# Altium schematic sheet 05_Analog.SchDoc
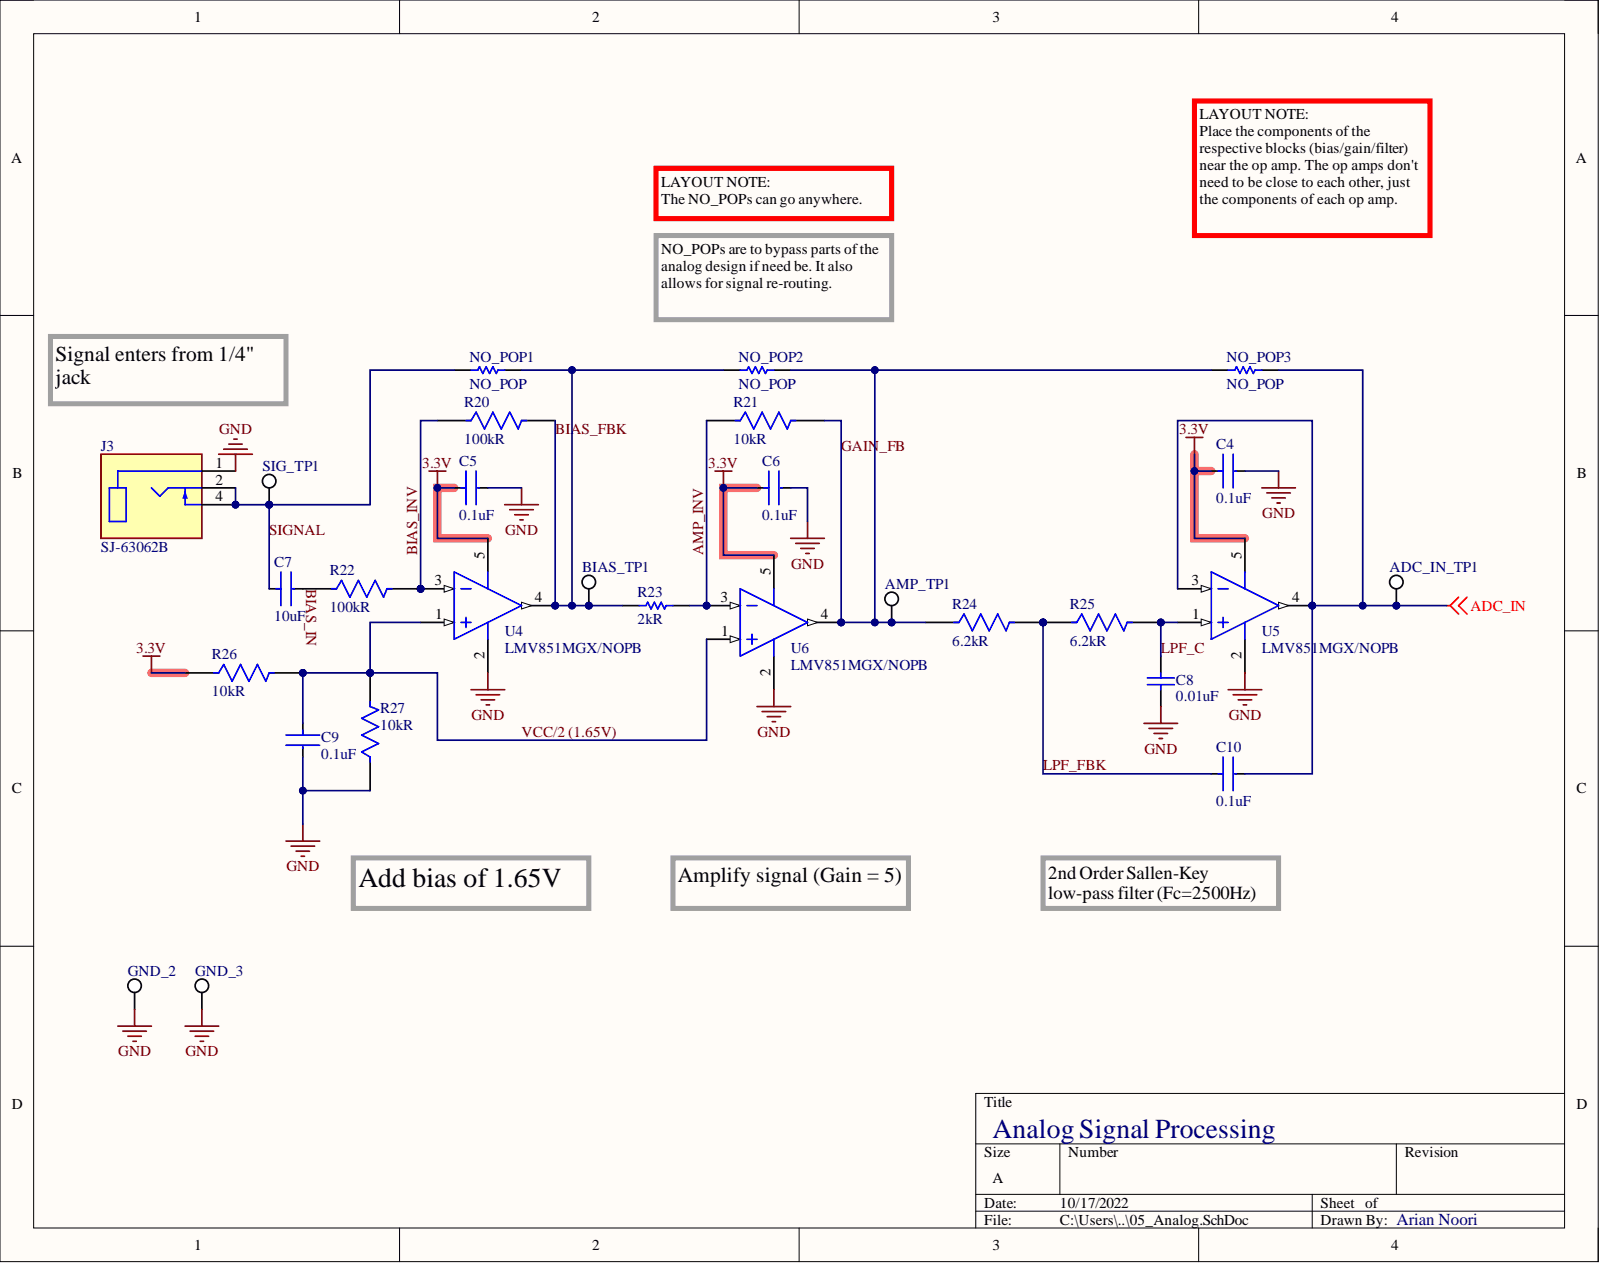
[diagram p0: 05_Analog.SchDoc]

## Parts
ADC_IN_TP1  5019  [KSTN-5019_V]  (value not drawn)
AMP_TP1  5019  [KSTN-5019_V]  (value not drawn)
BIAS_TP1  5019  [KSTN-5019_V]  (value not drawn)
C4  0.1uF  [FP-CL21-IPC_C]
C5  0.1uF  [FP-CL21-IPC_C]
C6  0.1uF  [FP-CL21-IPC_C]
C7  10uF  [FP-CL21-IPC_B]
C8  0.01uF  [CAPC2013X75X45LL10T25]
C9  0.1uF  [FP-CL21-IPC_C]
C10  0.1uF  [FP-CL21-IPC_C]
GND_2  5019  [KSTN-5019_V]  (value not drawn)
GND_3  5019  [KSTN-5019_V]  (value not drawn)
J3  SJ-63062B  [CUI_SJ-63062B]
NO_POP1  NO_POP  [RESC2013X60X35ML10T20]
NO_POP2  NO_POP  [RESC2013X60X35ML10T20]
NO_POP3  NO_POP  [RESC2013X60X35ML10T20]
R20  100kR  [FP-RT0805-MFG]
R21  10kR  [FP-RT0805-MFG]
R22  100kR  [FP-RT0805-MFG]
R23  2kR  [RESC2013X60X35LL10T20]
R24  6.2kR  [FP-RT0805-MFG]
R25  6.2kR  [FP-RT0805-MFG]
R26  10kR  [FP-RT0805-MFG]
R27  10kR  [FP-RT0805-MFG]
SIG_TP1  5019  [KSTN-5019_V]  (value not drawn)
U4  LMV851MGX/NOPB  [DCK0005A_N]
U5  LMV851MGX/NOPB  [DCK0005A_N]
U6  LMV851MGX/NOPB  [DCK0005A_N]

## Nets
3.3V: C4.1 C5.1 C6.1 R26.1 U4.5 U5.5 U6.5
ADC_IN: ADC_IN_TP1.1 C10.2 NO_POP3.2 U5.3 U5.4
GND: C4.2 C5.2 C6.2 C8.2 C9.2 GND_2.1 GND_3.1 J3.1 R27.1 U4.2 U5.2 U6.2
AMP_INV: R21.1 R23.2 U6.3
BIAS_FBK: BIAS_TP1.1 NO_POP1.2 NO_POP2.1 R20.2 R23.1 U4.4
BIAS_IN: C7.2 R22.1
BIAS_INV: R20.1 R22.2 U4.3
GAIN_FB: AMP_TP1.1 NO_POP2.2 NO_POP3.1 R21.2 R24.1 U6.4
LPF_C: C8.1 R25.2 U5.1
LPF_FBK: C10.1 R24.2 R25.1
SIGNAL: C7.1 J3.2 J3.4 NO_POP1.1 SIG_TP1.1
VCC/2 (1.65V): C9.1 R26.2 R27.2 U4.1 U6.1

## Library data of the parts
ADC_IN_TP1 AMP_TP1 BIAS_TP1 GND_2 GND_3 SIG_TP1 = CMP-1672-00008-1: Test Point, 1 Position SMD, RoHS, Tape and Reel; Manufacturer: Keystone Electronics; Category: Connectors; Component Kind: Standard; ComponentLink1Description: Manufacturer URL; ComponentLink1URL: http://www.keyelco.com/; ComponentLink2Description: Datasheet; ComponentLink2URL: http://www.keyelco.com/product-pdf.cfm?p=1357; ComponentLink3Description: https://datasheet.ciiva.com/16318/m60-2p61-16318395.pdf?src-supplier=Future+Electronics; ComponentLink3URL: https://datasheet.ciiva.com/16318/m60-2p61-16318395.pdf?src-supplier=Future+Electronics; ComponentLink4Description: https://datasheet.ciiva.com/16885/70182998-16885945.pdf?src-supplier=Allied+Electronics; ComponentLink4URL: https://datasheet.ciiva.com/16885/70182998-16885945.pdf?src-supplier=Allied+Electronics; ComponentLink5Description: https://datasheet.ciiva.com/14848/m60-2p61-14848437.pdf?src-supplier=Digi-Key; ComponentLink5URL: https://datasheet.ciiva.com/14848/m60-2p61-14848437.pdf?src-supplier=Digi-Key; ComponentLink6Description: https://datasheet.ciiva.com/14848/m60-2p61-14848438.pdf?src-supplier=Digi-Key; ComponentLink6URL: https://datasheet.ciiva.com/14848/m60-2p61-14848438.pdf?src-supplier=Digi-Key; ComponentLink7Description: https://datasheet.ciiva.com/14848/m60-2p61-14848439.pdf?src-supplier=Digi-Key; ComponentLink7URL: https://datasheet.ciiva.com/14848/m60-2p61-14848439.pdf?src-supplier=Digi-Key; Contact Material: Bronze; Contact Plating: Silver; DatasheetVersion: 03/2014; Height: 0.09inch; Lead Free: Lead Free; Library Name: Altium Content Vault; Manufacturer Part Number: 5019; Material: Bronze; Mount: Surface Mount; Mounting Technology: Surface Mount; Number of Pins: 1; Package Quantity: 1000; PackageDescription: 1-Pin Surface Mount Device, Body 3.81 x 2.03 mm; PackageReference: 5019; Packaging: Tape and Reel; Pins: 1; Pricing 1: 1=0.34000, 25=0.27360, 100=0.22340, 250=0.20064, 500=0.19152 (USD); REACH SVHC: No SVHC; RoHS: Yes; RoHS Compliant: Yes; RoHSCompliant: True; Series: 5019; Stock 1: 1295398; Supplier 1: Digi-Key; Termination: SMD/SMT
C4-C6 C9 C10 = CMP-2000-05017-2: CL21 Series 0805 100nF 50V ±10% Tolerance X7R Multilayer Ceramic Chip Capacitor; Manufacturer: Samsung; Manufacturer Part Number: CL21B104KBCNNNC; Capacitance: 100nF; Case Code (Imperial): 0805; Case Code (Metric): 2012; Case/Package: 0805; Category: Ceramic Capacitors; Component Kind: Standard; ComponentLink1Description: https://datasheet.ciiva.com/25710/cl21b104kbcnnnc-25710182.pdf?src-supplier=Digi-Key; ComponentLink1URL: https://datasheet.ciiva.com/25710/cl21b104kbcnnnc-25710182.pdf?src-supplier=Digi-Key; ComponentLink2Description: https://datasheet.ciiva.com/29199/454152-da-01-en-vs-kondensator-0805-29199814.pdf?src-supplier=Conrad; ComponentLink2URL: https://datasheet.ciiva.com/29199/454152-da-01-en-vs-kondensator-0805-29199814.pdf?src-supplier=Conrad; Construction: Flat; Contact Plating: Tin; Depth: 1.25mm; Dielectric: X7R; Height: 0.85mm; Lead Free: Lead Free; Length: 2mm; Library Name: Altium Content Vault; Material: Ceramic; Max Operating Temperature: 125°C; Min Operating Temperature: -55°C; Mount: Surface Mount; Packaging: Tape and Reel; Pricing 1: 1=0.10000, 10=0.04400, 50=0.02400, 100=0.01960, 500=0.01404, 1000=0.01103 (USD); Radiation Hardening: No; RoHS: Yes; RoHS Compliant: Yes; Series: CL; Stock 1: 4599648; Supplier 1: Digi-Key; Thickness: 0.037inch; Tolerance: 10%; Voltage: 50V; Voltage Rating: 50V; Voltage Rating (DC): 50V; Width: 0.049inch
C7 = CMP-2000-06103-2: CL21 Series 0805 10uF 10V ±10% Tolerance X5R Multilayer Ceramic Chip Capacitor; Manufacturer: Samsung; Manufacturer Part Number: CL21A106KPFNNNE; Capacitance: 10uF; Case Code (Imperial): 0805; Case Code (Metric): 2012; Case/Package: 0805; Category: Ceramic Capacitors; Component Kind: Standard; ComponentLink1Description: https://media.digikey.com/pdf/Data%20Sheets/Samsung%20PDFs/CL_Series_MLCC_ds.pdf?src-supplier=Digi-Key; ComponentLink1URL: https://media.digikey.com/pdf/Data%20Sheets/Samsung%20PDFs/CL_Series_MLCC_ds.pdf?src-supplier=Digi-Key; Construction: Flat; Contact Plating: Tin; Depth: 1.25mm; Dielectric: X5R; Height: 1.25mm; Lead Free: Lead Free; Length: 2mm; Library Name: Altium Content Vault; Max Operating Temperature: 85°C; Min Operating Temperature: -55°C; Mount: Surface Mount; Package Quantity: 1; Packaging: Tape and Reel; Pricing 1: 1=0.11000, 10=0.08100, 50=0.04420, 100=0.03610, 500=0.02580, 1000=0.02028 (USD); Radiation Hardening: No; RoHS: Yes; RoHS Compliant: Yes; Series: CL; Stock 1: 2691681; Supplier 1: Digi-Key; Thickness: 0.053inch; Tolerance: 10%; Voltage Rating: 10V; Voltage Rating (DC): 10V; Width: 0.049inch
C8 = CMP-2000-06105-1: Multilayer Ceramic Capacitor, 10 nF, 50 V, -55 to 125 degC, 2-Pin SMD, RoHS, Tape and Reel; Manufacturer: Samsung Electro-Mechanics; Manufacturer Part Number: CL21B103KBANNNC; Capacitance: 10000pF; Dielectric: X7R; Rated Voltage: 50V; Tolerance: 10%; Tolerance (%): ±10%; Case Code (Imperial): 0805; Case Code (Metric): 2012; Case Size/Dimension: 0805; Case/Package: 0805; Category: Ceramic Capacitors; Component Kind: Standard; ComponentLink1Description: https://datasheet.ciiva.com/25709/cl21b103kbannnc-25709781.pdf?src-supplier=Digi-Key; ComponentLink1URL: https://datasheet.ciiva.com/25709/cl21b103kbannnc-25709781.pdf?src-supplier=Digi-Key; ComponentLink2Description: https://datasheet.ciiva.com/29199/455082-da-01-en-vs-kondensator-0805-29199459.pdf?src-supplier=Conrad; ComponentLink2URL: https://datasheet.ciiva.com/29199/455082-da-01-en-vs-kondensator-0805-29199459.pdf?src-supplier=Conrad; Construction: Flat; Contact Plating: Tin; Datasheet URL: https://datasheet.ciiva.com/29199/455082-da-01-en-vs-kondensator-0805-29199459.pdf; Datasheet Version: 11/2010; Depth: 1.25mm; Height: 0.65mm; Lead Free: Lead Free; Length: 2mm; Library Name: Altium Content Vault; Manufacturer URL: http://www.samsungsem.com/global/index.jsp; Material: Ceramic; Max Operating Temperature: 125°C; Min Operating Temperature: -55°C; Mount: Surface Mount; Mounting Technology: SurfaceMount; Package Description: 2-Pin Surface Mount Device, Body 2 x 1.25 mm; Package Reference: 0805; Packaging: Tape and Reel; Pricing 1: 1=0.10000, 10=0.04200, 50=0.02300, 100=0.01880, 500=0.01344, 1000=0.01055 (USD); Radiation Hardening: No; RoHS: Yes; RoHS Compliant: Yes; Series: CL; Stock 1: 3435994; Supplier 1: Digi-Key; Thickness: 0.03inch; Voltage: 50V; Voltage Rating: 50V; Voltage Rating (DC): 50V; Width: 0.049inch
J3 = SJ-63062B: 6.35 mm, Mono and Stereo, Right Angle, Through Hole, 2~3 Conductors, 0~1 Internal Switches, Audio Jack Connector; Manufacturer: CUI Devices; Availability: Unavailable; Category: Audio / Video Connectors; Component Kind: Standard; Library Name: SJ-63062B.SchLib; Manufacturer Part Number: SJ-63062B; MP: SJ-63062B; Package: None; Price: None; Pricing 1: 1=2.09000, 10=1.82100, 25=1.74360, 50=1.70500, 150=1.62747, 300=1.47250, 600=1.35625, 1050=1.16250, 2550=1.08500 (USD); RoHS: Yes; Stock 1: 732; Supplier 1: Digi-Key
NO_POP1-NO_POP3 = CMP-2100-03673-1: Chip Resistor, 0 Ohm, Jumper, +/- 5%, 0.125 W, -55 to 155 degC, 0805 (2012 Metric), RoHS, Tape and Reel; Manufacturer: Yageo; Manufacturer Part Number: RC0805JR-070RL; Max Power Dissipation: 125mW; Resistance: 0mOhm; Temperature Coefficient: 200ppm/degreesC; Voltage Rating: 150V; Case Code (Imperial): 0805; Case Code (Metric): 2012; Case/Package: 0805; Category: Chip SMD Resistors; Component Kind: Standard; ComponentLink1Description: https://datasheet.ciiva.com/11819/0900766b8106beab-11819430.pdf?src-supplier=RSComponents; ComponentLink1URL: https://datasheet.ciiva.com/11819/0900766b8106beab-11819430.pdf?src-supplier=RSComponents; ComponentLink2Description: https://datasheet.ciiva.com/7941/1738694-7941861.pdf?src-supplier=Element14; ComponentLink2URL: https://datasheet.ciiva.com/7941/1738694-7941861.pdf?src-supplier=Element14; ComponentLink3Description: https://datasheet.ciiva.com/7941/1738694-7941862.pdf?src-supplier=Element14; ComponentLink3URL: https://datasheet.ciiva.com/7941/1738694-7941862.pdf?src-supplier=Element14; ComponentLink4Description: https://datasheet.ciiva.com/7941/1738694-7941863.pdf?src-supplier=Element14; ComponentLink4URL: https://datasheet.ciiva.com/7941/1738694-7941863.pdf?src-supplier=Element14; ComponentLink5Description: https://datasheet.ciiva.com/15190/getdatasheetpartid-330685-15190028.pdf?src-supplier=Verical; ComponentLink5URL: https://datasheet.ciiva.com/15190/getdatasheetpartid-330685-15190028.pdf?src-supplier=Verical; Composition: ThickFilm; Construction: Rectangular; Contact Plating: Tin; Datasheet URL: http://www.yageo.com/NewPortal/yageodocoutput?fileName=/pdf/R-Chip/PYu-RC_Group_51_RoHS_L_5.pdf; Datasheet Version: Rev. 5, 10/2016; Depth: 1.25mm; Features: Moisture Resistant; Height: 0.5mm; Lead Free: LeadFree; Length: 2mm; Library Name: Altium Content Vault; Manufacturer URL: http://www.yageo.com/; Max Operating Temperature: 155degC; Military Standard: Not; Min Operating Temperature: -55degC; Number of Pins: 2; Number of Terminations: 2; Package Description: 2-Pin Surface Mounted Device, Body 2 x 1.25 mm; Package Reference: 0805; Packaging: TapeandReel; Power Rating: 125mW; Pricing 1: 1=0.10000, 10=0.03100, 25=0.02280, 50=0.01720, 100=0.01280, 250=0.00972, 500=0.00778, 1000=0.00573 (USD); Radiation Hardening: No; REACH SVHC: Unknown; Resistance Tolerance: 5%; Resistor Type: Thick Film; RoHS: Yes; RoHS Compliant: Yes; RoHSCompliant: true; Series: RC; Size Code: 0805; Stock 1: 3029531; Supplier 1: Digi-Key; Technology: THICK FILM; Termination: SMD/SMT; Tolerance: 5%; Voltage Rating (DC): 150V; Width: 1.25mm
R20 R22 = CMP-03412-020019-1: RES 100K OHM 1/8W .5% SMD 0805; Manufacturer: Yageo; Manufacturer Part Number: RT0805DRE07100KL; Max Power Dissipation: 125mW; Power Rating: 0.125W; Resistance: 100KΩ; Temperature Coefficient: 50 ppm/°C; Tolerance: 0.01; Value: 100KΩ; Voltage Rating: 150V; Automotive: No; Case Code (Imperial): 0805; Case Code (Metric): 2012; Case/Package: 0805; Category: Res; Component Kind: Standard; ComponentLink1Description: http://www.yageo.com/documents/recent/PYu-RT_1-to-0.01_RoHS_L_9.pdf?src-supplier=Digi-Key; ComponentLink1URL: http://www.yageo.com/documents/recent/PYu-RT_1-to-0.01_RoHS_L_9.pdf?src-supplier=Digi-Key; ComponentLink2Description: Datasheet; ComponentLink2URL: http://www.yageo.com/documents/recent/PYu-RT_1-to-0.01_RoHS_L_9.pdf; Composition: Thin Film; Device Class L1: Passive Components; Device Class L2: Resistors; Device Class L3: Chip SMD Resistors; Digikey Description: RES 100K OHM 1/8W .5% SMD 0805; Features: Moisture Resistant; Footprint Url: http://www.yageo.com/exep/pages/download/literatures/PYu-R_Mount_10.pdf; Height: 0.6mm; Ipc Land Pattern Name: RESC200125X50; Lead Free: Yes; Length: 0.079inch; Library Name: Altium Content Vault; Max Operating Temperature: 155°C; Min Operating Temperature: -55°C; Number of Terminations: 2; Octopart: https://octopart.com/rt0805dre07100kl-yageo-1433075; Package: RT0805; Packaging: Tape and Reel; Pricing 1: 1=0.13000, 10=0.11500, 100=0.04430, 1000=0.01947, 2500=0.01682 (USD); Resistor Type: Thin Film; RoHS: TRUE; RoHS Compliant: Yes; Series: RT; Stock 1: 19530; Supplier 1: Digi-Key; Temperature: -55°C to +155°C; Temperature Range High: +155°C; Temperature Range Low: -55°C; Voltage Rating (DC): 150V; Width: 0.049inch
R21 R26 R27 = CMP-2001-01785-2: RES 10.0K OHM 1/8W .5% SMD 0805; Manufacturer: Yageo; Manufacturer Part Number: RT0805DRE0710KL; Max Power Dissipation: 125mW; Power Rating: 0.125W; Resistance: 10KΩ; Temperature Coefficient: 50 ppm/°C; Tolerance: 0.01; Value: 10KΩ; Voltage Rating: 150V; Automotive: No; Case Code (Imperial): 0805; Case Code (Metric): 2012; Case/Package: 0805; Category: Res; Component Kind: Standard; ComponentLink1Description: http://www.mouser.com/ds/2/447/yageodocoutput-1125656.pdf?src-supplier=Mouser; ComponentLink1URL: http://www.mouser.com/ds/2/447/yageodocoutput-1125656.pdf?src-supplier=Mouser; ComponentLink2Description: Datasheet; ComponentLink2URL: http://www.yageo.com/documents/recent/PYu-RT_1-to-0.01_RoHS_L_9.pdf; ComponentLink3Description: http://www.yageo.com/documents/recent/PYu-RT_1-to-0.01_RoHS_L_9.pdf?src-supplier=Digi-Key; ComponentLink3URL: http://www.yageo.com/documents/recent/PYu-RT_1-to-0.01_RoHS_L_9.pdf?src-supplier=Digi-Key; ComponentLink4Description: http://www.yageo.com/documents/recent/PYu-RT_1-to-0.05_RoHS_L_(4).pdf?src-supplier=Future+Electronics; ComponentLink4URL: http://www.yageo.com/documents/recent/PYu-RT_1-to-0.05_RoHS_L_(4).pdf?src-supplier=Future+Electronics; Composition: Thin Film; Construction: Rectangular; Depth: 1.25mm; Device Class L1: Passive Components; Device Class L2: Resistors; Device Class L3: Chip SMD Resistors; Digikey Description: RES 10.0K OHM 1/8W .5% SMD 0805; Features: Moisture Resistant; Footprint Url: http://www.yageo.com/exep/pages/download/literatures/PYu-R_Mount_10.pdf; Height: 0.6mm; Ipc Land Pattern Name: RESC200125X50; Lead Free: Yes; Length: 2mm; Library Name: Altium Content Vault; Max Operating Temperature: 125°C; Military Standard: Not; Min Operating Temperature: -55°C; Mouser Description: Res Thin Film 0805 10K Ohm 0.5% 1/8W ±50ppm/°C Molded SMD Paper T/R; Number of Terminations: 2; Octopart: https://octopart.com/rt0805dre0710kl-yageo-1180739; Package: RT0805; Package Quantity: 5000; Packaging: Tape and Reel; Pricing 1: 1=0.13000, 10=0.11500, 100=0.04430, 1000=0.01947, 2500=0.01682 (USD); Resistor Type: Thin Film; RoHS: TRUE; RoHS Compliant: Yes; Series: RT; Size Code: 0805; Stock 1: 92996; Supplier 1: Digi-Key; Temperature: -55°C to +155°C; Temperature Range High: +155°C; Temperature Range Low: -55°C; Termination: SMD/SMT; Voltage Rating (DC): 150V; Weight: 0.000194oz; Width: 1.25mm
R23 = CMP-2001-01475-1: RES 2K OHM 1/8W .5% SMD 0805; Manufacturer: Yageo; Manufacturer Part Number: RT0805FRE072KL; Max Power Dissipation: 125mW; Temperature Coefficient: 50ppm/°C; Tolerance: 1%; Voltage Rating: 150V; Case Code (Imperial): 0805; Case Code (Metric): 2012; Case/Package: 0805; Category: Chip SMD Resistors; Component Kind: Standard; ComponentLink1Description: https://datasheet.ciiva.com/12811/pyu-rt-1-to-0-05-rohs-l-4-12811661.pdf?src-supplier=Mouser; ComponentLink1URL: https://datasheet.ciiva.com/12811/pyu-rt-1-to-0-05-rohs-l-4-12811661.pdf?src-supplier=Mouser; ComponentLink2Description: https://datasheet.ciiva.com/2561/pyu-rt-1-to-0-05-rohs-l-5-2561141.pdf?src-supplier=Digi-Key; ComponentLink2URL: https://datasheet.ciiva.com/2561/pyu-rt-1-to-0-05-rohs-l-5-2561141.pdf?src-supplier=Digi-Key; Construction: Rectangular; Contact Plating: Tin,Lead,Matte; Depth: 1.25mm; Features: Moisture Resistant; Height: 0.024inch; Lead Free: Lead Free; Length: 2mm; Library Name: Altium Content Vault; Max Operating Temperature: 125°C; Military Standard: Not; Min Operating Temperature: -55°C; Number of Terminations: 2; Package Quantity: 5000; Packaging: Tape and Reel; Part Number: RT0805FRE072KL; Power Rating: 125mW; Pricing 1: 1=0.10000, 10=0.08400, 100=0.03250, 1000=0.01430, 2500=0.01235 (USD); Radiation Hardening: No; Resistance: 2kR; Resistor Type: Thin Film; RoHS: Yes; RoHS Compliant: Yes; Series: RT; Stock 1: 19626; Supplier 1: Digi-Key; Termination: SMD/SMT; Voltage Rating (DC): 150V; Weight: 0.000194oz; Width: 0.049inch
R24 R25 = CMP-2001-01500-2: RES SMD 6.2K OHM 1% 1/8W 0805; Manufacturer: Yageo; Manufacturer Part Number: RT0805FRE076K2L; Max Power Dissipation: 125mW; Power Rating: 0.125W; Resistance: 6.2KΩ; Temperature Coefficient: 50 ppm/°C; Tolerance: 0.0001; Value: 6.2KΩ; Voltage Rating: 150V; Automotive: No; Case/Package: 0805; Category: Res; Component Kind: Standard; ComponentLink1Description: https://datasheet.ciiva.com/2561/pyu-rt-1-to-0-05-rohs-l-5-2561365.pdf?src-supplier=Digi-Key; ComponentLink1URL: https://datasheet.ciiva.com/2561/pyu-rt-1-to-0-05-rohs-l-5-2561365.pdf?src-supplier=Digi-Key; ComponentLink2Description: Datasheet; ComponentLink2URL: http://www.yageo.com/documents/recent/PYu-RT_1-to-0.01_RoHS_L_9.pdf; Composition: Thin Film; Construction: Rectangular; Contact Plating: Tin,Matte; Depth: 1.25mm; Device Class L1: Passive Components; Device Class L2: Resistors; Device Class L3: Chip SMD Resistors; Digikey Description: RES SMD 6.2K OHM 1% 1/8W 0805; Footprint Url: http://www.yageo.com/exep/pages/download/literatures/PYu-R_Mount_10.pdf; Height: 0.6mm; Ipc Land Pattern Name: RESC200125X50; Lead Free: Yes; Length: 0.079inch; Library Name: Altium Content Vault; Max Operating Temperature: 125°C; Military Standard: Not; Min Operating Temperature: -55°C; Number of Terminations: 2; Octopart: https://octopart.com/rt0805fre076k2l-yageo-1397874; Package: RT0805; Package Quantity: 5000; Packaging: Tape and Reel; Pricing 1: 1=0.10000, 10=0.08400, 100=0.03250, 1000=0.01430, 2500=0.01235 (USD); Radiation Hardening: No; Resistor Type: Thin Film; RoHS: TRUE; RoHS Compliant: Yes; Series: RT; Stock 1: 49588; Supplier 1: Digi-Key; Temperature: -55°C to +155°C; Temperature Range High: +155°C; Temperature Range Low: -55°C; Voltage Rating (DC): 150V; Width: 0.049inch
U4-U6 = CMP-0055-01104-3: Single 8 MHz Low Power CMOS, EMI Hardened Operational Amplifier, 5-pin SC-70, Pb-Free; Manufacturer: Texas Instruments; Amplifier Type: GENERAL PURPOSE; Common Mode Rejection Ratio: 77dB; CompType: Amplifiers; Gain Bandwidth Product: 8MHz; Input Bias Current: 0.1pA; Input Offset Voltage (Vos): 260uV; Max Supply Voltage: 5.5V; Min Supply Voltage: 2.7V; Nominal Supply Current: 400uA; Number of Channels: 1; Operating Supply Current: 430uA; Output Current: 30mA; Output Current per Channel: 65mA; PackageTopMarking: A98*XTT; PowerWise: Y; Slew Rate: 4.5V/µs; Voltage Gain: 120dB; Case/Package: SC; Category: Amplifiers - Op Amps, Buffer, Instrumentation; Code_IPC: SOT353-5; Code_JEDEC: MO-203-AA; Code_JEITA: SC-88A; Component Kind: Standard; ComponentLink1Description: Datasheet URL; ComponentLink1URL: http://www.ti.com/general/docs/lit/getliterature.tsp?genericPartNumber=LMV851&fileType=pdf; ComponentLink2Description: https://datasheet.ciiva.com/14460/lmv851-14460668.pdf?src-supplier=Avnet; ComponentLink2URL: https://datasheet.ciiva.com/14460/lmv851-14460668.pdf?src-supplier=Avnet; ComponentLink3Description: https://datasheet.ciiva.com/6627/lmv851-6627003.pdf?src-supplier=Digi-Key; ComponentLink3URL: https://datasheet.ciiva.com/6627/lmv851-6627003.pdf?src-supplier=Digi-Key; ComponentLink4Description: https://datasheet.ciiva.com/6626/lmv851-6626998.pdf?src-supplier=Digi-Key; ComponentLink4URL: https://datasheet.ciiva.com/6626/lmv851-6626998.pdf?src-supplier=Digi-Key; Lead Free: Lead Free; Library Name: Altium Content Vault; Manufacturer Part Number: LMV851MGX/NOPB; Max Operating Temperature: 125°C; Min Operating Temperature: -40°C; Mount: Surface Mount; Number of Circuits: 1; Number of Pins: 5; Package Quantity: 1; Package Reference: DCK0005A; PackageDescription: Molded SC-70, 2 x 1.25 x .9mm, 5 Lead, 0.65mm Pitch; Packaging: Tape and Reel; PinCount: 5; Pricing 1: 1=2.08000, 10=1.87100, 25=1.76480, 100=1.50370, 250=1.41192, 500=1.23544, 1000=1.06560 (USD); Radiation Hardening: No; RoHS: Y; RoHS Compliant: Yes; Series: PowerWise®; Stock 1: 2870; Supplier 1: Digi-Key; Supply Current: 400uA; Technology: CMOS
